# Altium schematic sheet connection_SPI_FLash.SchDoc
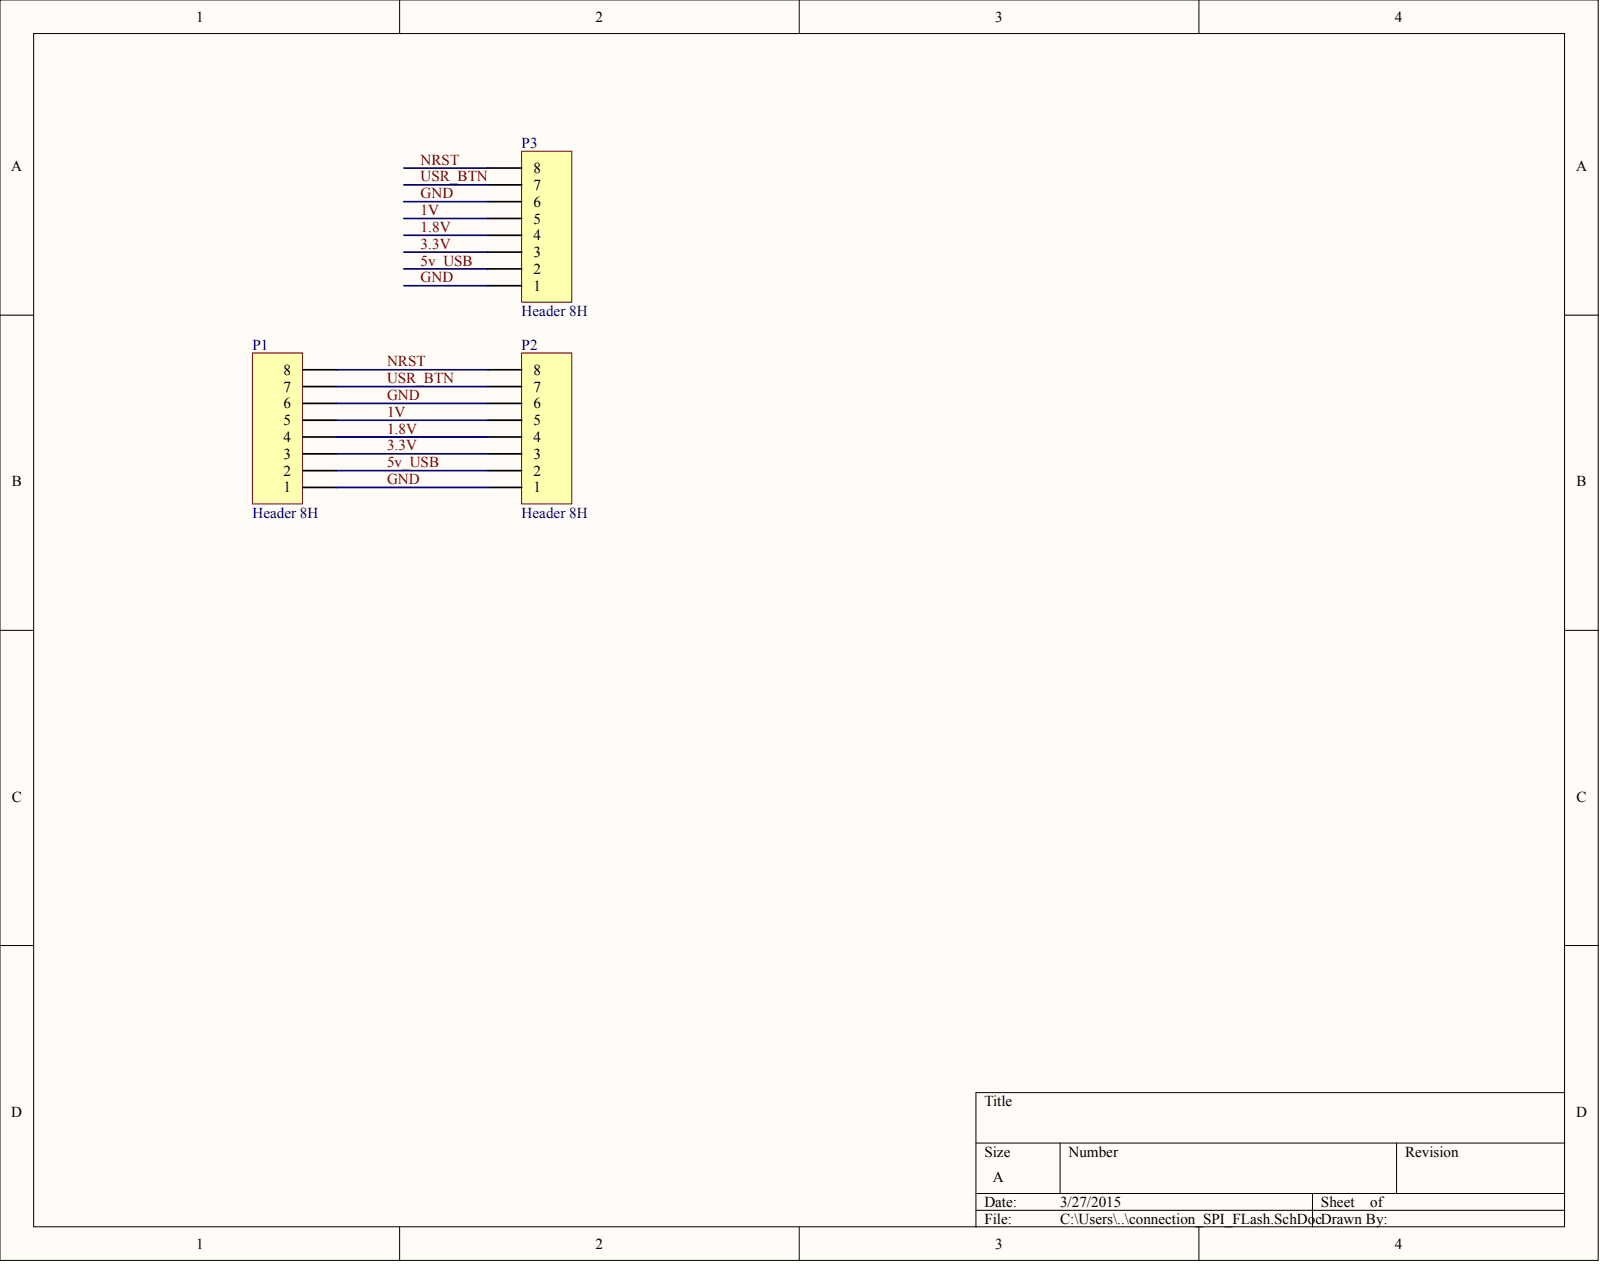
[diagram p0: connection_SPI_FLash.SchDoc]

## Parts
P1  Header 8H  [Header_8_Right]
P2  Header 8H  [Header_8_Right]
P3  Header 8H  [Header_8_Right]

## Nets
1.8V: P1.4 P2.4 P3.4
1V: P1.5 P2.5 P3.5
3.3V: P1.3 P2.3 P3.3
5V_USB: P1.2 P2.2 P3.2
GND: P1.1 P1.6 P2.1 P2.6 P3.1 P3.6
NRST: P1.8 P2.8 P3.8
USR_BTN: P1.7 P2.7 P3.7

## Library data of the parts
P1-P3 = Header 8H: Header, 8-Pin, Right Angle_Silkscreen_fixed; Component Kind: Standard; LatestRevisionDate: 17-Jul-2002; LatestRevisionNote: Re-released for DXP Platform.; Signal Integrity: Connector; Library Name: Linux_Board_SCHEM_LIB.SchLib; Publisher: Altium Limited
